# Altium schematic sheet 5.04.51805A02_RKPower.SchDoc(5.04.51805A02_RKPower)
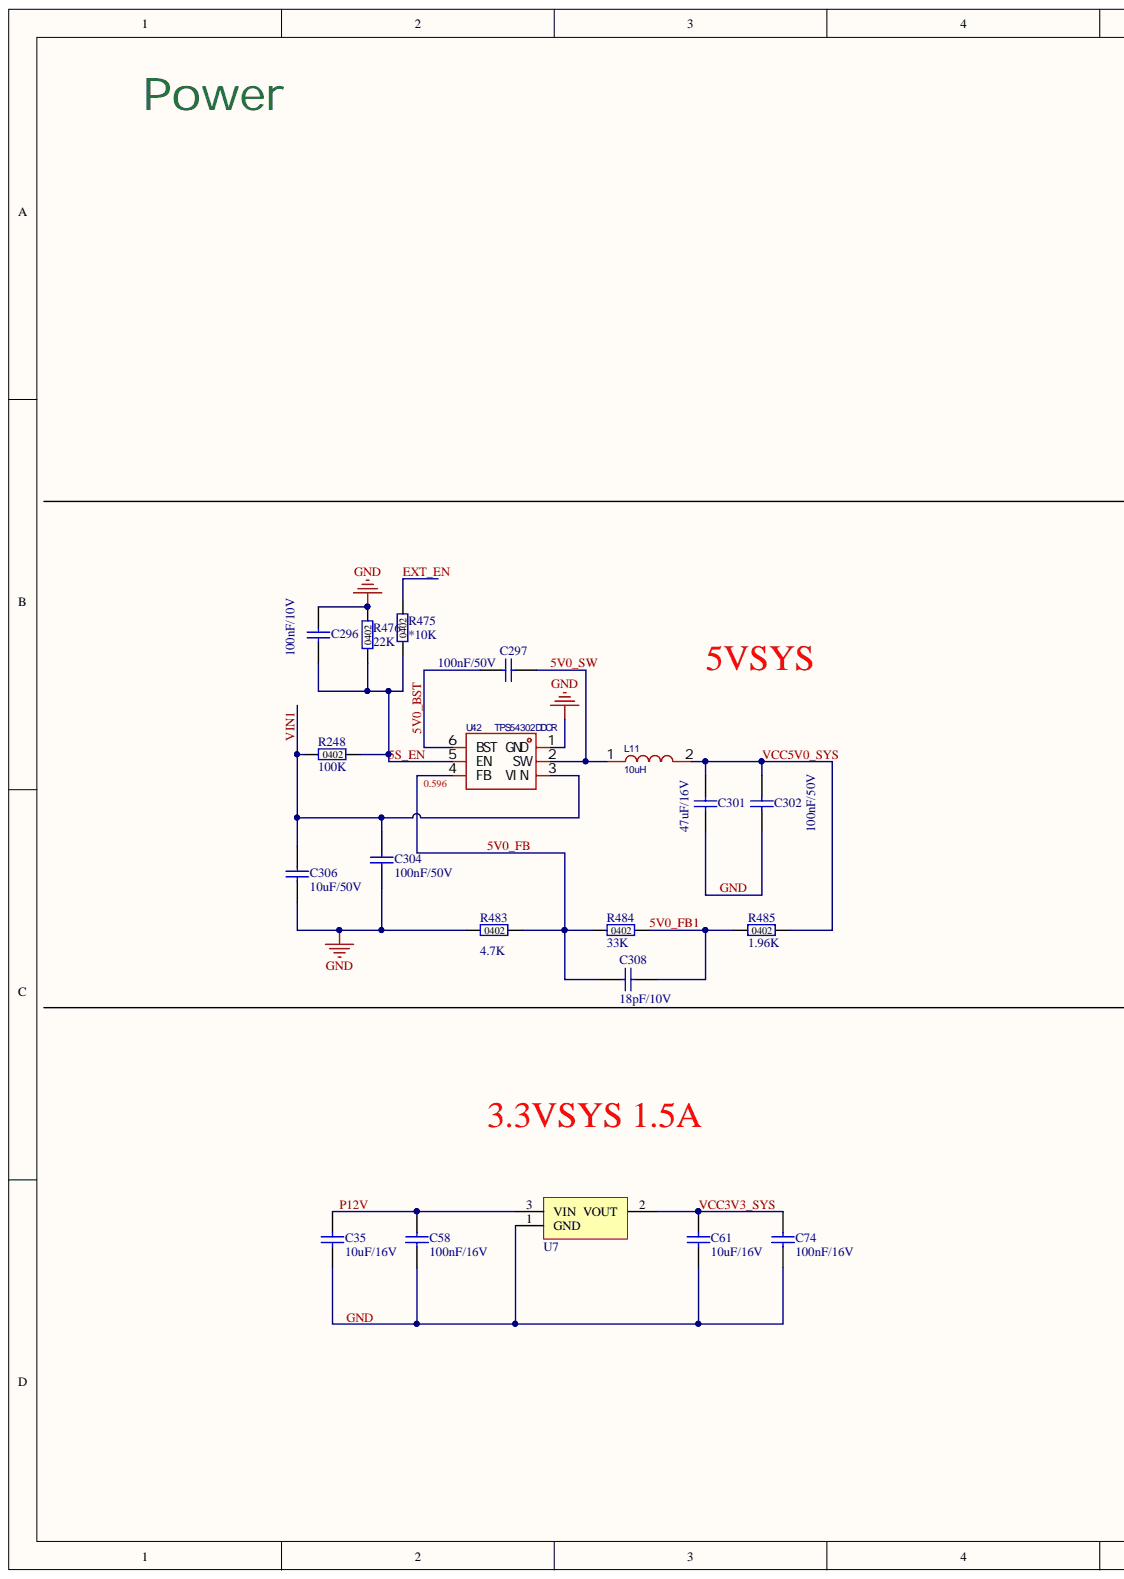
[diagram p0: 5.04.51805A02_RKPower.SchDoc, left]
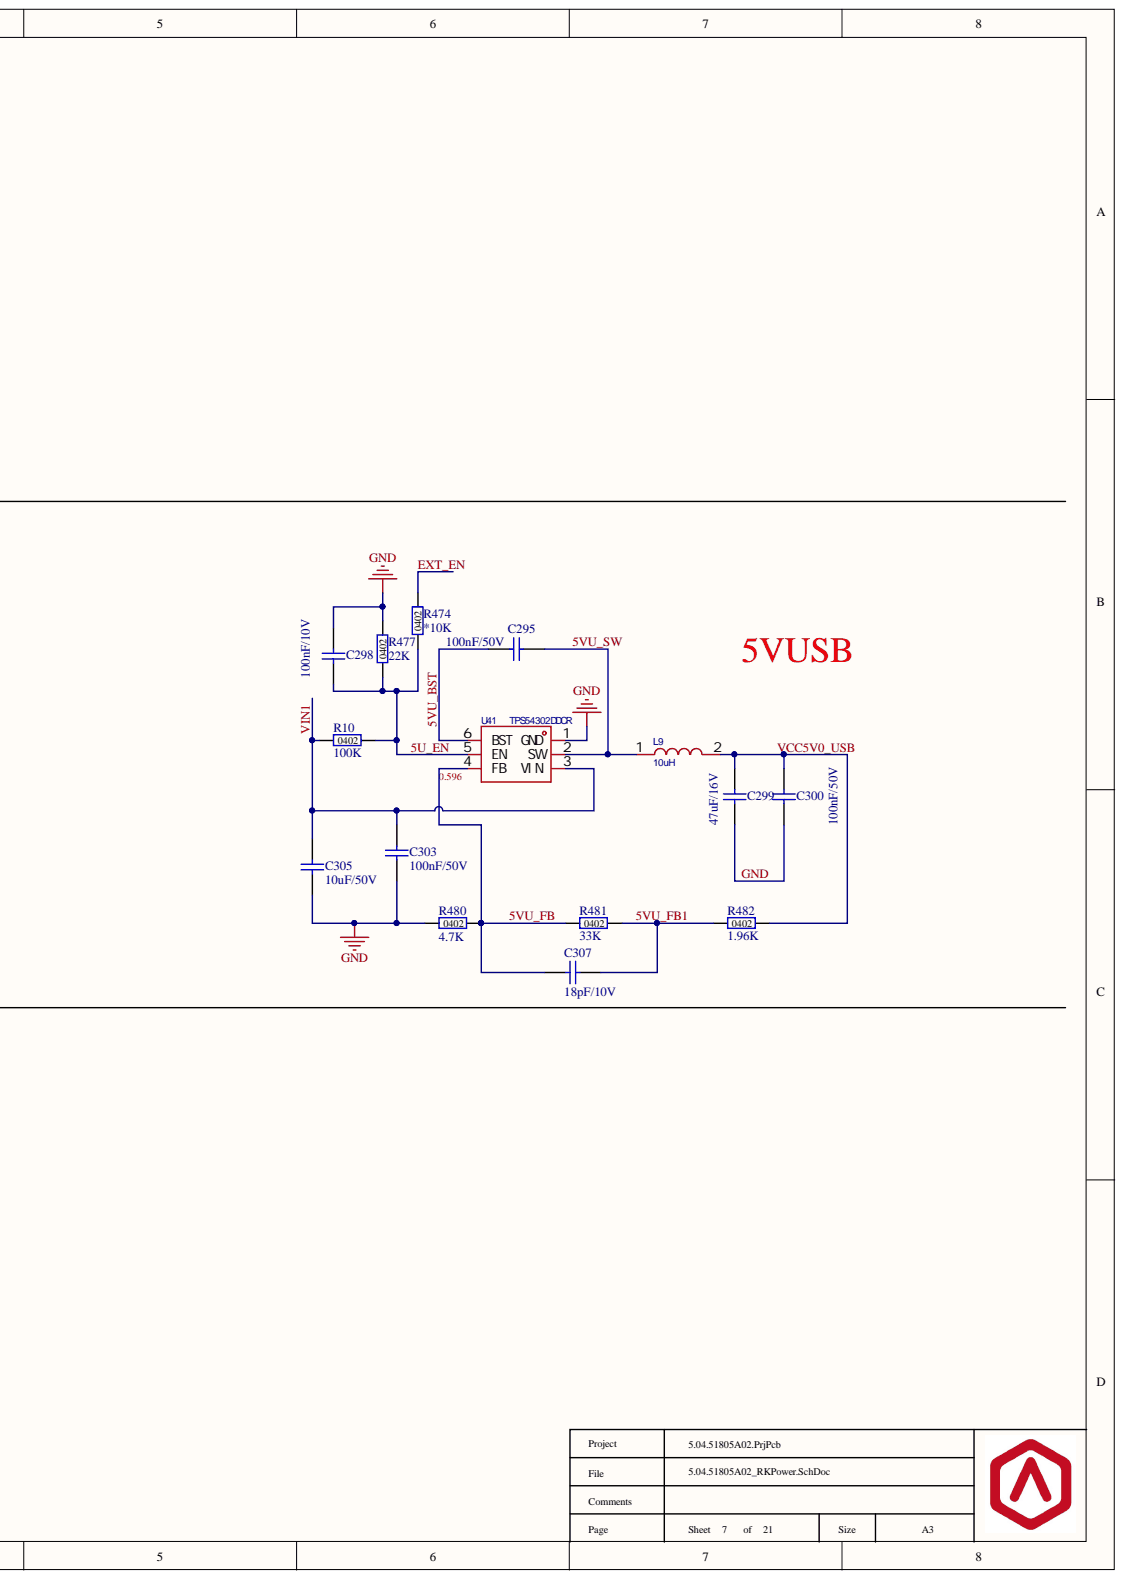
[diagram p1: 5.04.51805A02_RKPower.SchDoc, right]

## Parts
C35  10uF/16V  [C0603]
C58  100nF/16V  [C0402]
C61  10uF/16V  [C0603]
C74  100nF/16V  [C0402]
C295  100nF/50V  [C0603]
C296  100nF/10V  [C0402]
C297  100nF/50V  [C0603]
C298  100nF/10V  [C0402]
C299  47uF/16V  [C1210]
C300  100nF/50V  [C0603]
C301  47uF/16V  [C1210]
C302  100nF/50V  [C0603]
C303  100nF/50V  [C0603]
C304  100nF/50V  [C0603]
C305  10uF/50V  [C0805]
C306  10uF/50V  [C0805]
C307  18pF/10V  [C0402]
C308  18pF/10V  [C0402]
L9  10uH  [IND-SMD_L5.4-W5.2_FXL0530]
L11  10uH  [IND-SMD_L5.4-W5.2_FXL0530]
R10  100K  [R0402]
R248  100K  [R0402]
R474  *10K  [R0402]
R475  *10K  [R0402]
R476  22K  [R0402]
R477  22K  [R0402]
R480  4.7K  [R0402]
R481  33K  [R0402]
R482  1.96K  [R0402]
R483  4.7K  [R0402]
R484  33K  [R0402]
R485  1.96K  [R0402]
U7  AMS1086CD-3.3  [TO-252-2_L6.6-W6.1-P4.57-LS9.9-TL-CW]  (value not drawn)
U41  TPS54302DDCR  [TSOT-23-6_L2.9-W1.6-P0.95-LS2.8-BL]
U42  TPS54302DDCR  [TSOT-23-6_L2.9-W1.6-P0.95-LS2.8-BL]

## Nets
5S_EN: C296.1 R248.2 R475.1 R476.1 U42.5(EN)
5U_EN: C298.1 R10.2 R474.1 R477.1 U41.5(EN)
5V0_BST: C297.1 U42.6(BST)
5V0_FB: C308.1 R483.2 R484.1 U42.4(FB)
5V0_FB1: C308.2 R484.2 R485.1
5V0_SW: C297.2 L11.1 U42.2(SW)
5VU_BST: C295.1 U41.6(BST)
5VU_FB: C307.1 R480.2 R481.1 U41.4(FB)
5VU_FB1: C307.2 R481.2 R482.1
5VU_SW: C295.2 L9.1 U41.2(SW)
EXT_EN: R474.2 R475.2
GND: C35.1 C58.1 C61.1 C74.1 C296.2 C298.2 C299.1 C300.1 C301.1 C302.1 C303.1 C304.1 C305.1 C306.1 R476.2 R477.2 R480.1 R483.1 U7.1 U41.1(GND) U42.1(GND)
P12V: C35.2 C58.2 U7.3
VCC3V3_SYS: C61.2 C74.2 U7.2
VCC5V0_SYS: C301.2 C302.2 L11.2 R485.2
VCC5V0_USB: C299.2 C300.2 L9.2 R482.2
VIN1: C303.2 C304.2 C305.2 C306.2 R10.1 R248.1 U41.3(VIN) U42.3(VIN)

## Library data of the parts
C35 C61 = C0603_10uF_16V: Ceramic Capacitor, 10uF, ±10%, 16V, -55°C~85°C, C0603; Manufacturer1: SAMSUNG; ManufacturerPartNumber1: CL10A106KO8NQNC; Code: 5172C106; Component Kind: Standard; Library Name: Raise3D_Capacitor.IntLib
C58 C74 = C0402_100nF_16V: Ceramic Capacitor, 100nF, ±10%, 16V, -55°C~125°C, C0402; Manufacturer1: Yageo; ManufacturerPartNumber1: CC0402KPX7R7BB104; Code: 5171C104; Component Kind: Standard; Library Name: Raise3D_Capacitor.IntLib
C295 C297 C300 C302-C304 = C0603_100nF_50V: Ceramic Capacitor, 100nF, ±10%, 50V, -55°C~125°C, C0603; Manufacturer1: Yageo; ManufacturerPartNumber1: CC0603KRX7R9BB104; Code: 5192C104; Component Kind: Standard; Library Name: Raise3D_Capacitor.IntLib
C296 C298 = C0402_100nF_10V: Ceramic Capacitor, 100nF, ±10%, 10V, -55°C~125°C, C0402; Manufacturer1: MuRata; ManufacturerPartNumber1: GRM155R71A104KA01D; Code: 5161C104; Component Kind: Standard; Library Name: Raise3D_Capacitor.IntLib
C299 C301 = C1210_47uF_16V: Ceramic Capacitor, 47uF, ±10%, 16V, -55°C~85°C, C1210; Manufacturer1: MuRata; ManufacturerPartNumber1: GRM32ER61C476KE15L; Code: 5175C476; Component Kind: Standard; Library Name: Raise3D_Capacitor.IntLib
C305 C306 = C0805_10uF_50V: Ceramic Capacitor, 10uF, ±10%, 50V, -55°C~85°C, C0805; Manufacturer1: Yageo; ManufacturerPartNumber1: CC0805KKX5R9BB106; Code: 5193C106; Component Kind: Standard; Library Name: Raise3D_Capacitor.IntLib
C307 C308 = C0402_18pF_10V: Ceramic Capacitor, 18pF, ±5%, 10V, -55°C~125°C, C0402; Manufacturer1: KEMET; ManufacturerPartNumber1: C0402C180J8GAC7867; Code: 5161C180; Component Kind: Standard; Library Name: Raise3D_Capacitor.IntLib
L9 L11 = FXL0530-100-M: 3.5A,SMD,5.2x5.4mm,10uH,60mΩ; Manufacturer1: cjiang(长江微电); Component Kind: Standard; ManufacturerPartNumber1: FXL0530-100-M; Library Name: QQQ.IntLib
R10 R248 = R0402_100K: Resistor 100Kohm,1%,1/16W,R0402; Manufacturer1: Yageo; ManufacturerPartNumber1: RC0402FR-07100KL; Code: 5001100K; Component Kind: Standard; Manufacturer2: Samsung; ManufacturerPartNumber2: RC1005F104CS; Library Name: Raise3D_Resistor.IntLib
R474 R475 = R0402_10K: Resistor 10Kohm,1%,1/16W,R0402; Manufacturer1: Yageo; ManufacturerPartNumber1: RC0402FR-0710KL; Code: 5001010K; Component Kind: Standard; Manufacturer2: Samsung; ManufacturerPartNumber2: RC1005F103CS; Library Name: Raise3D_Resistor.IntLib
R476 R477 = R0402_22K: Resistor 22Kohm,1%,1/16W,R0402; Manufacturer1: Samsung; ManufacturerPartNumber1: RC1005F223CS; Code: 5001022K; Component Kind: Standard; Library Name: Raise3D_Resistor.IntLib
R480 R483 = R0402_4.7K: Resistor 4.7Kohm,5%,1/16W,R0402; Manufacturer1: Yageo; ManufacturerPartNumber1: RC0402JR-074K7L; Code: 501104K7; Component Kind: Standard; Manufacturer2: Samsung; ManufacturerPartNumber2: RCS1005J472CS; Library Name: Raise3D_Resistor.IntLib
R481 R484 = R0402_33K: Resistor 33Kohm,1%,1/16W,R0402; Manufacturer1: Yageo; ManufacturerPartNumber1: RC0402FR-0733KL; Code: 5001033K; Component Kind: Standard; Library Name: Raise3D_Resistor.IntLib
R482 R485 = R0402_1.96K: Resistor 1.96Kohm,1%,1/16W,R0402; Manufacturer1: Yageo; ManufacturerPartNumber1: RC1005F1961CS; Code: 50011K96; Component Kind: Standard; Library Name: Raise3D_Resistor.IntLib
U7 = AMS1086CD-3.3: LDO,12V/3.3V,1.5A,0℃~125℃,TO-252-2; Manufacturer1: AMS; Code: C14241; Component Kind: Standard; ManufacturerPartNumber1: AMS1086CD-3.3; Library Name: Raise3D_General.IntLib
U41 U42 = TPS54302DDCR: 28V输入,3A同步降压DC-DC转换器; Manufacturer1: TI; Component Kind: Standard; ManufacturerPartNumber1: TPS54302DDCR; Library Name: QQQ.IntLib
